# Altium schematic sheet 原理图1.SchDoc(原理图1)
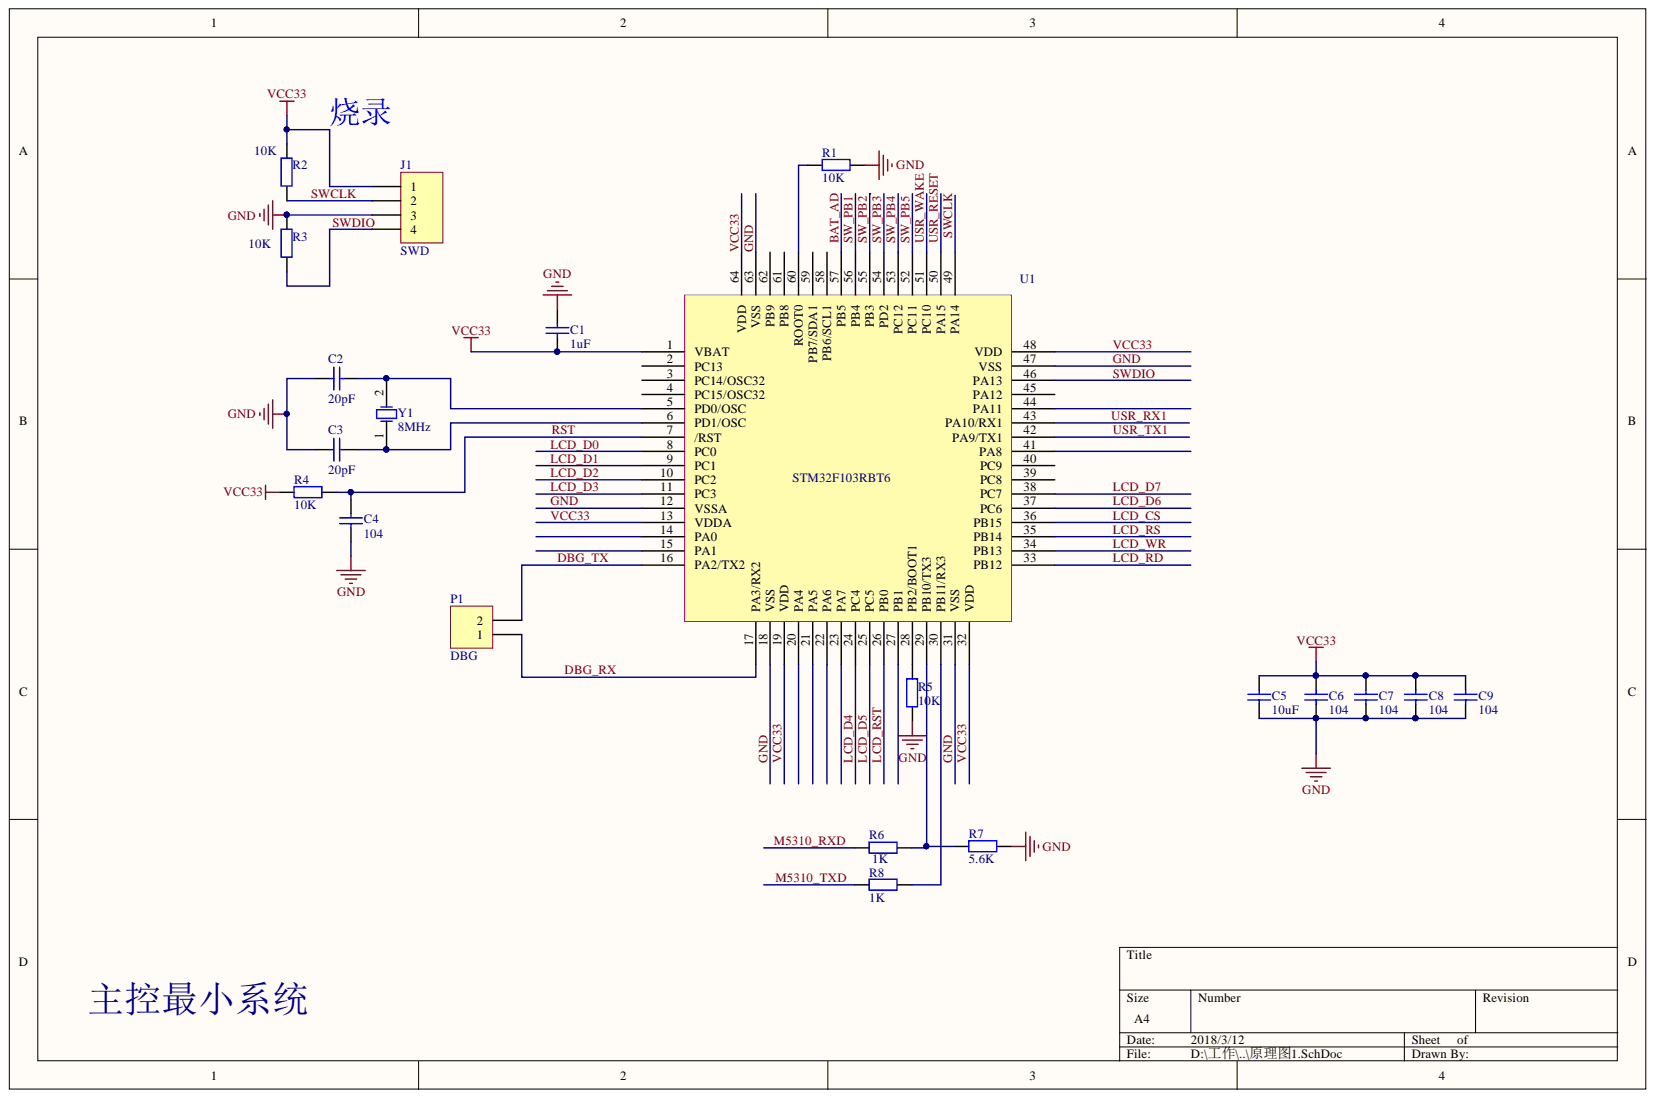
[diagram p0: 原理图1.SchDoc]

## Parts
C1  1uF  [0402]
C2  20pF  [0402]
C3  20pF  [0402]
C4  104  [0402]
C5  10uF  [0402]
C6  104  [0402]
C7  104  [0402]
C8  104  [0402]
C9  104  [0402]
J1  SWD  [HDR1X4]
P1  DBG  [HDR1X2]
R1  10K  [0402]
R2  10K  [0402]
R3  10K  [0402]
R4  10K  [0402]
R5  10K  [0402]
R6  1K  [0402]
R7  5.6K  [0402]
R8  1K  [0402]
U1  STM32F103RBT6  [STM32F103RBT6]
Y1  8MHz  [OSC2PR_SMD]

## Nets
BAT_AD: U1.57(PB5)
DBG_RX: P1.1 U1.17(PA3/RX2)
DBG_TX: P1.2 U1.16(PA2/TX2)
GND: C1.2 C2.1 C3.1 C4.1 C5.2 C6.2 C7.2 C8.2 C9.2 J1.3 R1.2 R3.2 R5.1 R7.2 U1.12(VSSA) U1.18(VSS) U1.31(VSS) U1.47(VSS) U1.63(VSS)
LCD_CS: U1.36(PB15)
LCD_D0: U1.8(PC0)
LCD_D1: U1.9(PC1)
LCD_D2: U1.10(PC2)
LCD_D3: U1.11(PC3)
LCD_D4: U1.24(PC4)
LCD_D5: U1.25(PC5)
LCD_D6: U1.37(PC6)
LCD_D7: U1.38(PC7)
LCD_RD: U1.33(PB12)
LCD_RS: U1.35(PB14)
LCD_RST: U1.26(PB0)
LCD_WR: U1.34(PB13)
M5310_RXD: R6.1
M5310_TXD: R8.2
RST: C4.2 R4.1 U1.7(/RST)
SW_PB1: U1.56(PB4)
SW_PB2: U1.55(PB3)
SW_PB3: U1.54(PD2)
SW_PB4: U1.53(PC12)
SW_PB5: U1.52(PC11)
SWCLK: J1.2 R2.1 U1.49(PA14)
SWDIO: J1.4 R3.1 U1.46(PA13)
USR_RESET: U1.50(PA15)
USR_RX1: U1.43(PA10/RX1)
USR_TX1: U1.42(PA9/TX1)
USR_WAKE: U1.51(PC10)
VCC33: C1.1 C5.1 C6.1 C7.1 C8.1 C9.1 J1.1 R2.2 R4.2 U1.1(VBAT) U1.13(VDDA) U1.19(VDD) U1.32(VDD) U1.48(VDD) U1.64(VDD)
NetC2_2: C2.2 U1.5(PD0/OSC) Y1.2
NetC3_2: C3.2 U1.6(PD1/OSC) Y1.1
NetR1_1: R1.1 U1.60(ROOT0)
NetR5_2: R5.2 U1.28(PB2/BOOT1)
NetR6_2: R6.2 R7.1 U1.29(PB10/TX3)
NetR8_1: R8.1 U1.30(PB11/RX3)

Not connected: U1 2(PC13) 3(PC14/OSC32) 4(PC15/OSC32) 14(PA0) 15(PA1) 20(PA4) 21(PA5) 22(PA6) 23(PA7) 27(PB1) 39(PC8) 40(PC9) 41(PA8) 44(PA11) 45(PA12) 58(PB6/SCL1) 59(PB7/SDA1) 61(PB8) 62(PB9)

## Library data of the parts
C1-C9 = 0201: Component Kind: Standard; Library Name: Capacitor.IntLib
  C1: Value: 1uF
  C2 C3: Value: 20pF
  C4 C6-C9: Value: 104
  C5: Value: 10uF
J1 = 06030014: 插针、方脚、4PIN、单排、直式、2.54、5.84、2mmPCB、3A、公; Component Kind: Standard; Library Name: Connector.IntLib; Value: SWD
P1 = Header 2: Header, 2-Pin; Component Kind: Standard; LatestRevisionDate: 17-Jul-2002; LatestRevisionNote: Re-released for DXP Platform.; Library Name: Miscellaneous Connectors.IntLib; Signal Integrity: Connector; Publisher: Altium Limited
R1-R5 R7 R8 = 0101: Component Kind: Standard; Library Name: Resistor.IntLib
  R1 R5: Value: 10K
  R7: Value: 5.6K
  R8: Value: 1K
R6 = 0101: Value: 0603; Component Kind: Standard; Library Name: Resistor.IntLib
Y1 = XTAL: Crystal Oscillator; Component Kind: Standard; LatestRevisionDate: 17-Jul-2002; LatestRevisionNote: Re-released for DXP Platform.; Library Name: Miscellaneous Devices.IntLib; PackageDescription: Crystal, Thru-Hole; 2 Leads; Body 3.1 x 8.2 mm (Dia.xH); PackageReference: R38; Published: 8-Jun-2000; Publisher: Altium Limited
U1 = STM32F103RBT6: Component Kind: Standard; Description: STM32F103RBT6; Library Name: GPRS.SchLib
